# Altium schematic sheet AudioPlayer.SchDoc
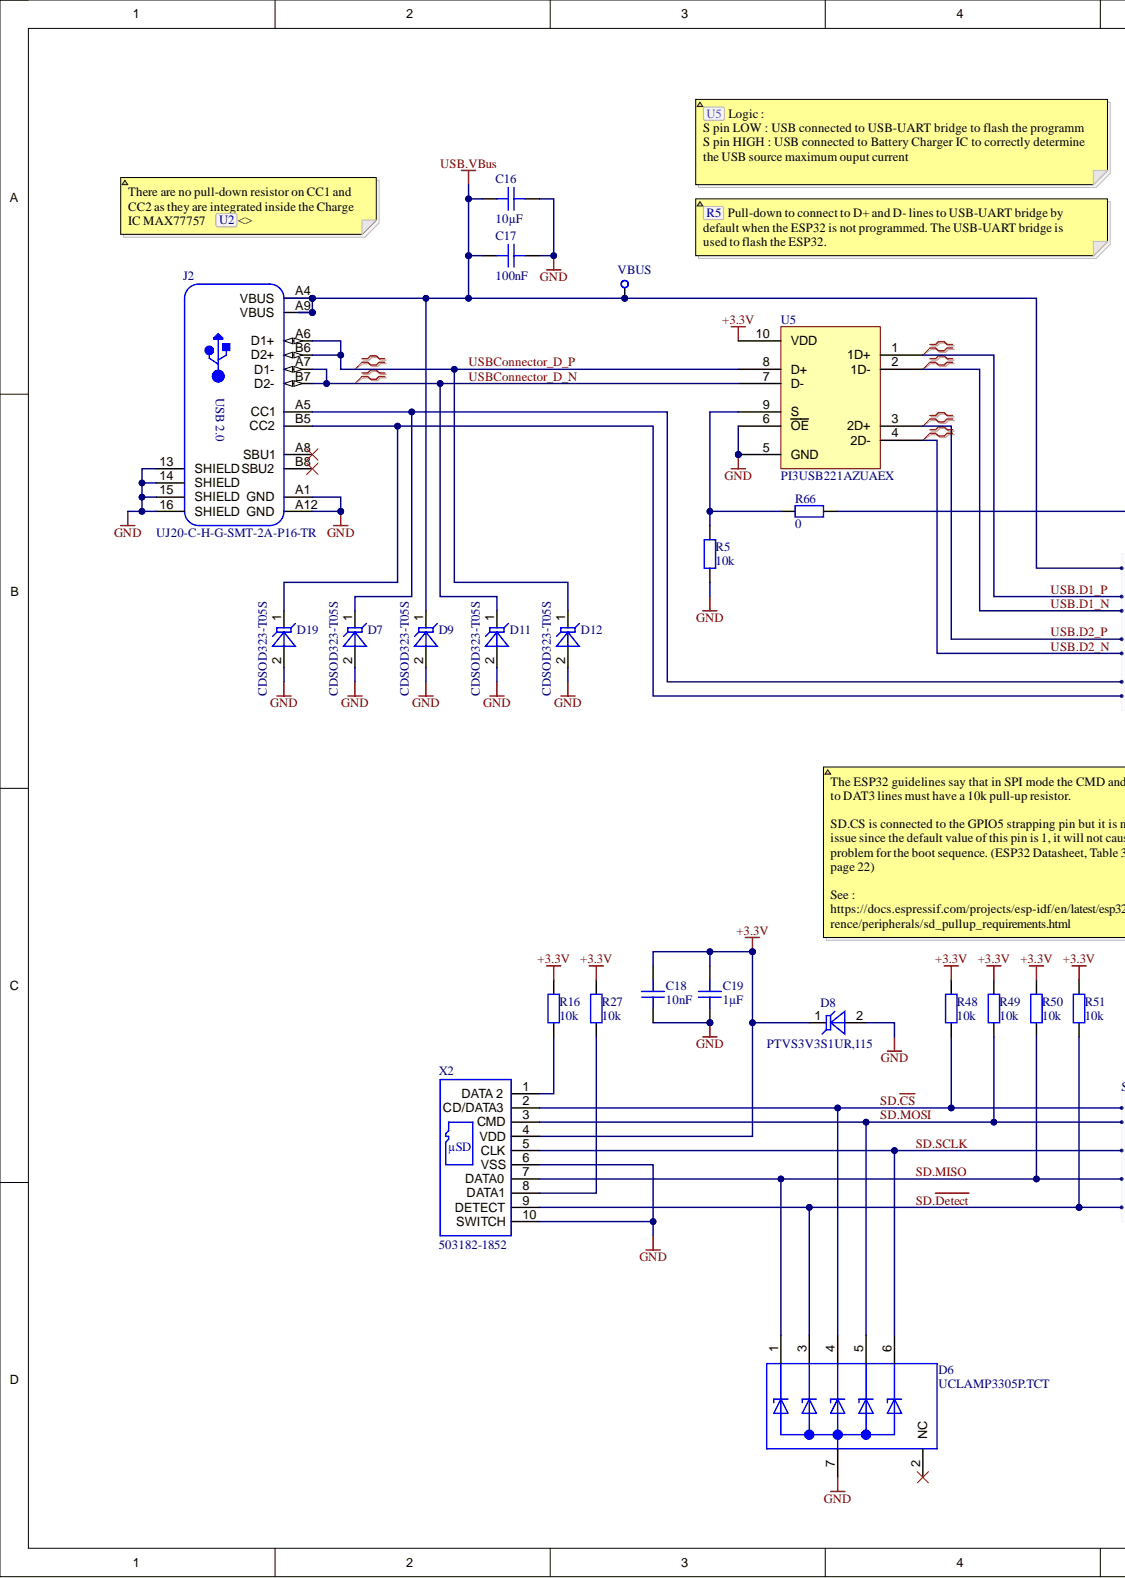
[diagram p0: AudioPlayer.SchDoc, left]
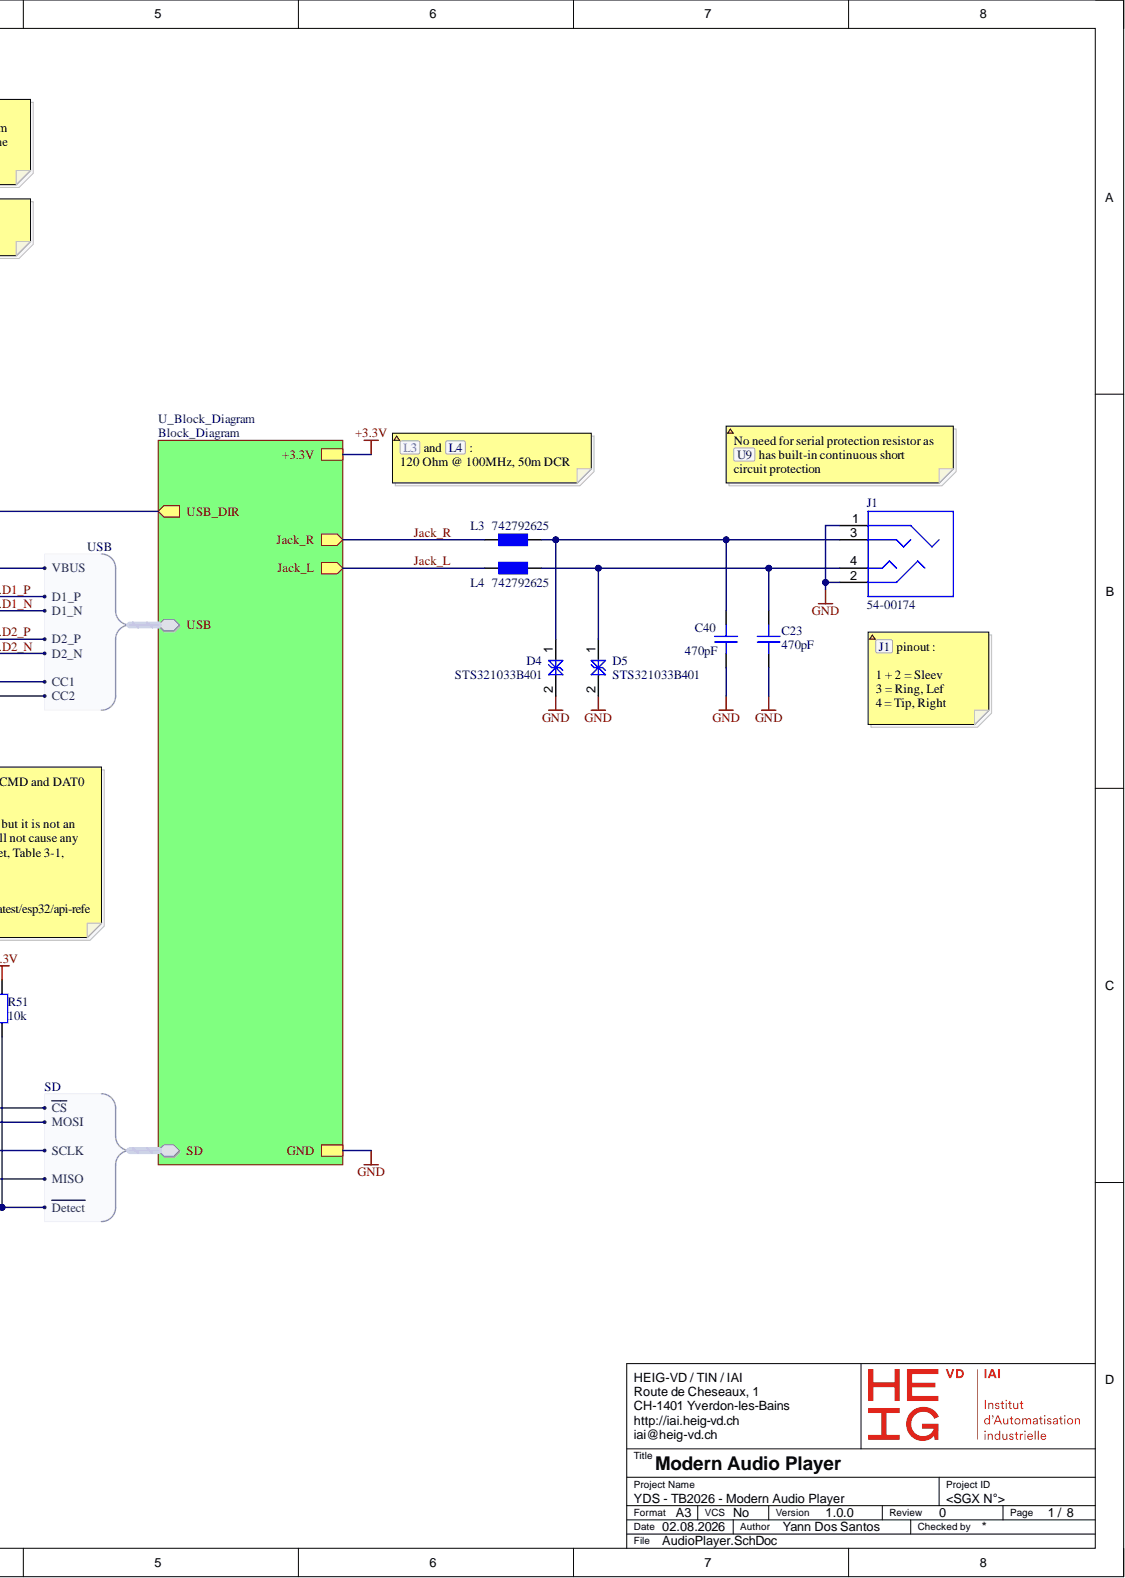
[diagram p1: AudioPlayer.SchDoc, right]

## Parts
C16  10µF  [CAPC1608X10N]
C17  100nF  [CAPC1608X10N]
C18  10nF  [CAPC2012X12N]
C19  1µF  [CAPC1608X10N]
C23  470pF  [CAPC1608X10N]
C40  470pF  [CAPC1608X10N]
D4  STS321033B401  [SOD260X100-2N]
D5  STS321033B401  [SOD260X100-2N]
D6  UCLAMP3305P.TCT  [TVS_UCLAMP3305P.TCT]
D7  CDSOD323-T05S  [SODFL250X110-2N]
D8  PTVS3V3S1UR,115  [SODFL350X110-2N]
D9  CDSOD323-T05S  [SODFL250X110-2N]
D11  CDSOD323-T05S  [SODFL250X110-2N]
D12  CDSOD323-T05S  [SODFL250X110-2N]
D19  CDSOD323-T05S  [SODFL250X110-2N]
J1  54-00174  [54-00174]
J2  UJ20-C-H-G-SMT-2A-P16-TR  Specification: USB 2.0  [UJ20-C-H-G-SMT]
L3  742792625  [INDC1608X10N]
L4  742792625  [INDC1608X10N]
R5  10k  [RESC1608X06N]
R16  10k  [RESC1608X06N]
R27  10k  [RESC1608X06N]
R48  10k  [RESC1608X06N]
R49  10k  [RESC1608X06N]
R50  10k  [RESC1608X06N]
R51  10k  [RESC1608X06N]
R66  0  [RESC1608X04N]
U5  PI3USB221AZUAEX  [PI3USB221AZUAEX]
VBUS  ICT Test Point - TH Round Pad  [TPICTTH09N]  (value not drawn)  (no BOM)
X2  503182-1852  [503182-1852]

## Nets
+3.3V: C18.2 C19.2 D8.1 R16.2 R27.2 R48.2 R49.2 R50.2 R51.2 U5.10(VDD) X2.4(VDD)
GND: C16.2 C17.2 C18.1 C19.1 C23.1 C40.1 D4.2 D5.2 D6.7 D7.2 D8.2 D9.2 D11.2 D12.2 D19.2 J1.1 J1.2 J2.13(SHIELD) J2.14(SHIELD) J2.15(SHIELD) J2.16(SHIELD) J2.A1(GND) J2.A12(GND) R5.1 U5.5(GND) U5.6(OE) X2.6(VSS) X2.10(SWITCH)
USB.VBus: C16.1 C17.1 D9.1 J2.A4(VBUS) J2.A9(VBUS) VBUS.1
Jack_L: L4.2
Jack_R: L3.2
SD.~{CS}: D6.4 R48.1 X2.2(CD/DATA3)
SD.~{Detect}: D6.3 R51.1 X2.9(DETECT)
SD.MISO: D6.1 R50.1 X2.7(DATA0)
SD.MOSI: D6.5 R49.1 X2.3(CMD)
SD.SCLK: D6.6 X2.5(CLK)
USB.D1_N: U5.2(1D-)
USB.D1_P: U5.1(1D+)
USB.D2_N: U5.4(2D-)
USB.D2_P: U5.3(2D+)
USBConnector_D_N: D11.1 J2.A7(D1-) J2.B7(D2-) U5.7(D-)
USBConnector_D_P: D12.1 J2.A6(D1+) J2.B6(D2+) U5.8(D+)
NetC23_2: C23.2 D5.1 J1.4 L4.1
NetC40_2: C40.2 D4.1 J1.3 L3.1
NetD6_2: D6.2(NC)
NetD7_1: D7.1 J2.A5(CC1)
NetD19_1: D19.1 J2.B5(CC2)
NetJ2_A8: J2.A8(SBU1)
NetJ2_B8: J2.B8(SBU2)
NetR5_2: R5.2 R66.1 U5.9(S)
NetR16_1: R16.1 X2.1(DATA_2)
NetR27_1: R27.1 X2.8(DATA1)
NetR66_2: R66.2

## Library data of the parts
C16 = CMP-0004123-1: 10 µF ±20% 35V Ceramic Capacitor X5R 0603 (1608 Metric); Case/Package: 0603 (1608 Metric); Component Kind: Standard; Max Operating Temperature: 85°C; Min Operating Temperature: -55°C; Mounting Technology: Surface Mount; RoHS Compliant: Yes; Temperature Coefficient: X5R; Tolerance: 20%; Value: 10µF; Voltage Rating: 35V; Library Name: HEIG-VD
C17 = CMP-0002275-1: 100nF ±10% 50V Ceramic Capacitor X8L 0603 (1608 Metric); Case/Package: 0603 (1608 Metric); Component Kind: Standard; Max Operating Temperature: 150°C; Min Operating Temperature: -55°C; Mounting Technology: Surface Mount; RoHS Compliant: Yes; Temperature Coefficient: X8L; Tolerance: 10%; Value: 100nF; Voltage Rating: 50V; Library Name: HEIG-VD
C18 = CMP-0000019-7: 10nF ±10% 50V Ceramic Capacitor X7R 0805 (2012 Metric); Case/Package: 0805 (2012 Metric); Component Kind: Standard; Max Operating Temperature: 125°C; Min Operating Temperature: -55°C; Mounting Technology: Surface Mount; RoHS Compliant: Yes; Temperature Coefficient: X7R; Tolerance: 10%; Value: 10nF; Voltage Rating: 50V; Library Name: HEIG-VD iAi
C19 = CMP-0006790-3: 1 µF ±10% 35V Ceramic Capacitor X7R 0603 (1608 Metric); Case/Package: 0603 (1608 Metric); Component Kind: Standard; Max Operating Temperature: 125°C; Min Operating Temperature: -55°C; Mounting Technology: Surface Mount; RoHS Compliant: Yes; Temperature Coefficient: X7R; Tolerance: 10%; Value: 1µF; Voltage Rating: 35V; Library Name: HEIG-VD
C23 C40 = CMP-0002277-1: 470pF ±1% 100V Ceramic Capacitor C0G, NP0 0603 (1608 Metric); Case/Package: 0603 (1608 Metric); Component Kind: Standard; Max Operating Temperature: 125°C; Min Operating Temperature: -55°C; Mounting Technology: Surface Mount; RoHS Compliant: Yes; Temperature Coefficient: C0G, NP0; Tolerance: 1%; Value: 470pF; Voltage Rating: 100V; Library Name: HEIG-VD iAi
D4 D5 = CMP-0007393-1: 15V Clamp 30A (8/20µs) Ipp Tvs Diode Surface Mount SOD-323; Bidirectionnal Channels: 1; Breakdown Voltage: 3.6V; Peak Pulse Power: 400W; Reverse Standoff Voltage: 3.3V; Type: Zener; Unidirectionnal Channels: 0; Applications: General Purpose; Case/Package: SC-76, SOD-323; Clamping Voltage @ Ipp: 15V; Component Kind: Standard; Max Operating Temperature: 125°C; Min Operating Temperature: -55°C; Mounting Technology: Surface Mount; Peak Pulse Current: 30A; RoHS Compliant: Yes; Library Name: HEIG-VD iAi
D6 = UCLAMP3305P.TCT: TVS DIODE 3.3VWM 8VC SLP1616P6; Manufacturer 1: Semtech Corporation; Component Kind: Standard; Manufacturer Part Number 1: UCLAMP3305P.TCT; Supplier 1: DigiKey; Supplier Part Number 1: 600-UCLAMP3305P.TCT-ND; Value: UCLAMP3305P.TCT; Library Name: TB2026-SchematictLib.SchLib
D7 D9 D11 D12 D19 = CMP-0002420-3: TVS Diode, 500W, 5V V(RWM), Unidirectional, 1 Element, 13.5V (Typ) Clamp 42A (8/20µs) Ipp Surface Mount SOD-323; Bidirectionnal Channels: 0; Breakdown Voltage: 6V; Type: Zener; Unidirectionnal Channels: 1; Applications: General Purpose; Case/Package: SOD-323; Clamping Voltage @ Ipp: 13.5V; Component Kind: Standard; Max Operating Temperature: 150°C; Min Operating Temperature: -55°C; Mounting Technology: Surface Mount; Peak Pulse Current: 42A; Peak Pulse Power: 500W; Reverse Standoff Voltage: 5V; RoHS Compliant: Yes; Library Name: HEIG-VD iAi
D8 = CMP-0002371-5: Trans Voltage Suppressor Diode, 400W, 3.3V V(RWM), Unidirectional, 1 Element, Silicon; Bidirectionnal Channels: 0; Breakdown Voltage: 5.2V; Clamping Voltage @ Ipp: 8V; Peak Pulse Current: 43.8A; Type: Surface Mount; Unidirectionnal Channels: 1; Applications: -; Case/Package: SOD-123W; Component Kind: Standard; Max Operating Temperature: 150°C; Min Operating Temperature: -55°C; Mounting Technology: Surface Mount; Peak Pulse Power: 400W; Reverse Standoff Voltage: 3.3V; RoHS Compliant: Yes; Library Name: HEIG-VD iAi
J1 = CMP-0007388-2: 3.50mm (0.141", 1/8", Mini Plug) - Headphone Phone Jack Stereo (4 Conductor, TRRS) Connector Solder; Current Rating: 500mA; Gender: Female; Internal Switch(s): Does Not Contain Switch; Number of Positions/Contacts: 4 Conductors, 4 Contacts; Shielding: Unshielded; Voltage Rating: 48V; Component Kind: Standard; Connector Type: Phone Jack; Features: Board Guide; Industry Recognized Mating Diameter: 3.50mm (0.141", 1/8", Mini Plug) - Headphone; Max Operating Temperature: 105°C; Min Operating Temperature: -40°C; Mounting Technology: Through Hole, Right Angle; RoHS Compliant: Yes; Signal Lines: Stereo (4 Conductor, TRRS); Library Name: HEIG-VD iAi
J2 = CMP-0007348-1: USB-C (USB TYPE-C) USB 2.0 Receptacle Connector 24 (16+8 Dummy) Position Surface Mount, Right Angle; Through Hole; Shielding: Shielded; Component Kind: Standard; Features: Board Guide, Solder Retention; Gender: Receptacle; Ingress Protection: -; Max Operating Temperature: 85°C; Min Operating Temperature: -40°C; Mounting Technology: Surface Mount, Right Angle; Through Hole; Number of Contacts: 24 (16+8 Dummy); RoHS Compliant: Yes; Type: USB-C (USB TYPE-C); Library Name: HEIG-VD iAi
L3 L4 = CMP-0006053-2: FERRITE BEAD 120 Ohms @ 100MHz 0603 1LN; Current Rating: 2A; DC Resistance: 50m; Case/Package: 0603; Component Kind: Standard; Impedance @ Frequency: 120Ohms @ 100MHz; Max Operating Temperature: 125°C; Min Operating Temperature: -55°C; Mounting Technology: Surface Mount; RoHS Compliant: Yes; Library Name: HEIG-VD iAi
R5 R16 R27 R48-R51 = CMP-0000997-4: 10 kOhms ±1% 0.1W, 1/10W Chip Resistor 0603 (1608 Metric) Moisture Resistant Thick Film; Power: 100mW; Resistor Series: E24; Temperature Coefficient: 200ppm/°C; Voltage Rating: 75V; Case/Package: 0603 (1608 Metric); Component Kind: Standard; Max Operating Temperature: 155°C; Min Operating Temperature: -55°C; Mounting Technology: Surface Mount; RoHS Compliant: Yes; Tolerance: 1%; Value: 10k; Library Name: HEIG-VD iAi
R66 = CMP-0002431-2: 0 Ohms ±1% 0.125W, 1/10W Chip Resistor 0603 (1608 Metric) Moisture Resistant Thick Film; Power: 100mW; Resistor Series: E24; Temperature Coefficient: 200ppm/°C; Voltage Rating: 150V; Case/Package: 0603 (1608 Metric); Component Kind: Standard; Max Operating Temperature: 155°C; Min Operating Temperature: -55°C; Mounting Technology: Surface Mount; RoHS Compliant: Yes; Tolerance: 1%; Value: 0; Library Name: HEIG-VD iAi
U5 = PI3USB221AZUAEX: IC USB2 SWITCH 3V UQFN2015-10; Manufacturer 1: Diodes Incorporated; Component Kind: Standard; Manufacturer Part Number 1: PI3USB221AZUAEX; Supplier 1: DigiKey; Supplier 2: Mouser; Supplier Part Number 1: PI3USB221AZUAEXDICT-ND; Supplier Part Number 2: 729-PI3USB221AZUAEX; Value: PI3USB221AZUAEX; Library Name: TB2026-SchematictLib.SchLib
VBUS = CMP-0005616-2: Through hole test point round pad for in-circuit test; Mounting Technology: Not Applicable; Case/Package: No Case; Colour: No Colour; Max Operating Temperature: 125°C; Min Operating Temperature: -55°C; NetName: NetName; RoHS Compliant: Yes; Library Name: HEIG-VD iAi
X2 = CMP-0002414-2: MicroSD Card Connector, 8+2 Contact(s), 1 Row(s), Male, Straight, 0.043 inch Pitch, Surface Mount Terminal; Card Type: Secure Digital - microSD™; Connector Type: Connector and Ejector; Insertion, Removal Method: Push In, Push Out; Number of Positions: 10; Component Kind: Standard; Ejector Side: -; Features: Switch; Max Operating Temperature: 85°C; Min Operating Temperature: -25°C; Mounting Feature: Normal, Standard - Top; Mounting Technology: SMD; RoHS Compliant: Yes; Library Name: HEIG-VD iAi
